# Altium schematic sheet Switcheo - on_off.SchDoc
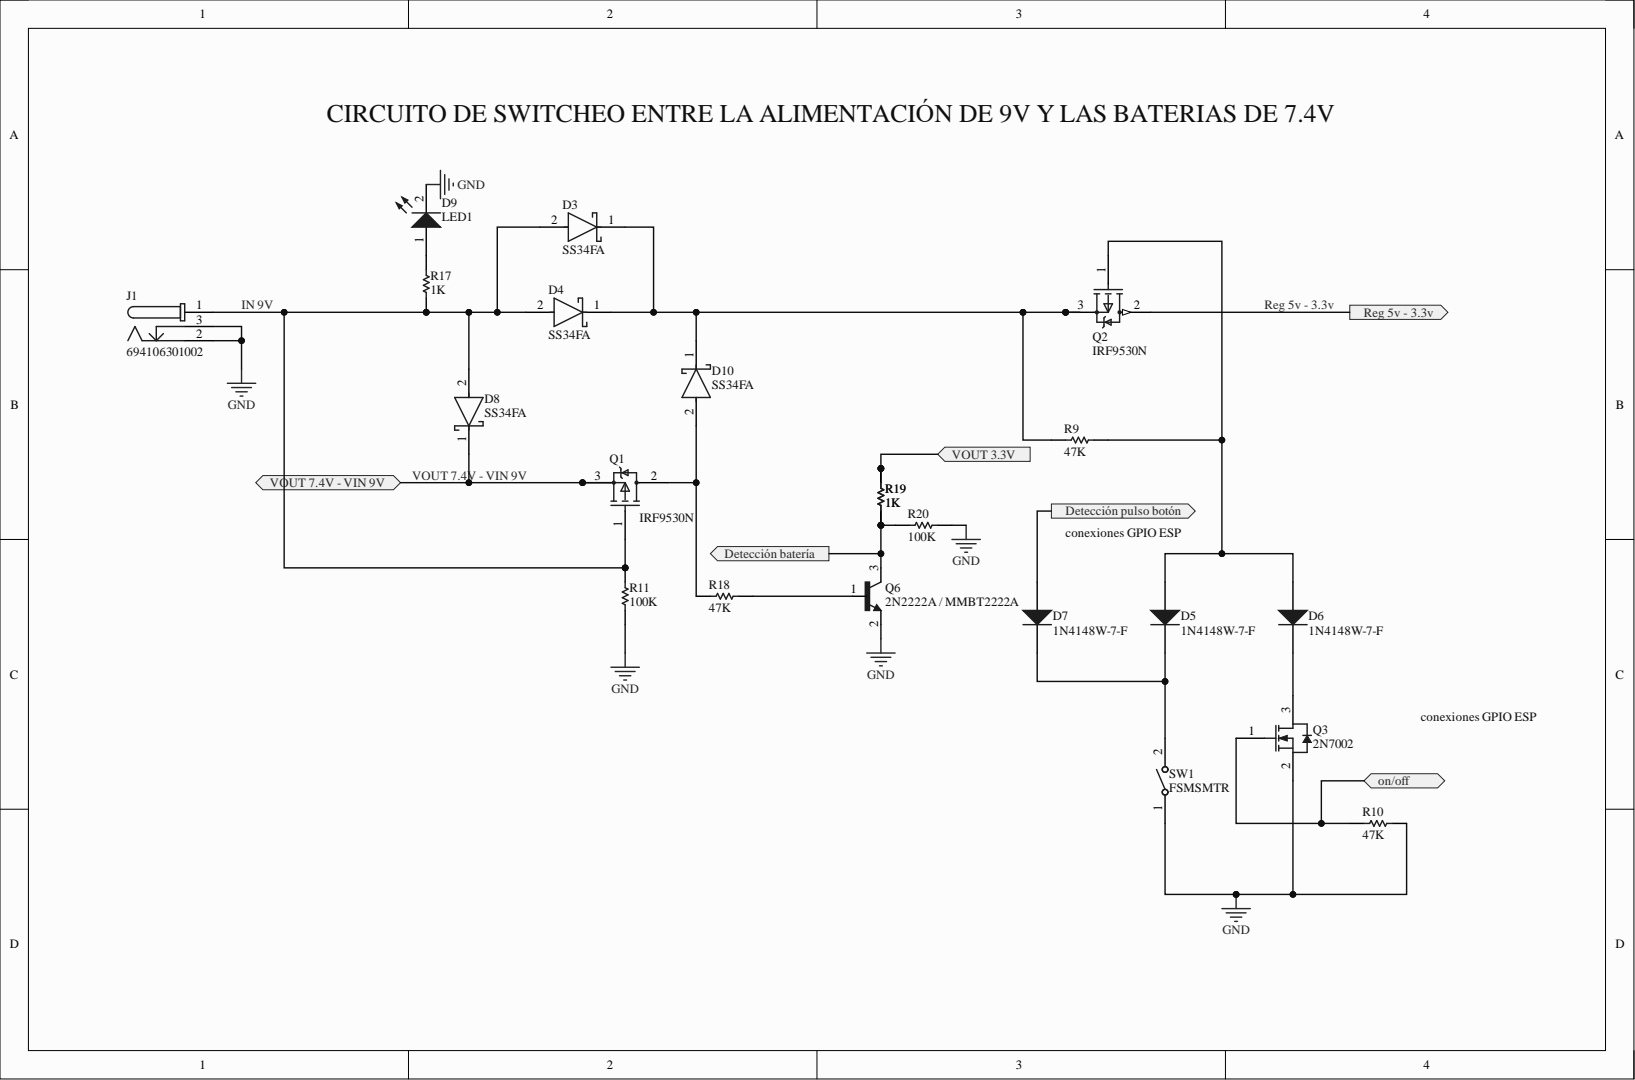
[diagram p0: Switcheo - on_off.SchDoc]

## Parts
D3  SS34FA  [Diodo_SS34]
D4  SS34FA  [Diodo_SS34]
D5  1N4148W-7-F  [1N4148]
D6  1N4148W-7-F  [1N4148]
D7  1N4148W-7-F  [1N4148]
D8  SS34FA  [Diodo_SS34]
D9  LED1  [Led0805 Verde]
D10  SS34FA  [Diodo_SS34]
J1  694106301002  [JACK DC]
Q1  IRF9530N  [IRF9530N THT]
Q2  IRF9530N  [IRF9530N THT]
Q3  2N7002  [2N7002]
Q6  2N2222A / MMBT2222A  [2N2222A / MMBT2222 SMD]
R9  value 47K  [RES 1206]  (hidden comment: 1%)
R10  value 47K  [RES 1206]  (hidden comment: 1%)
R11  1%  value 100K  [RES 1206]
R17  1%  value 1K  [RES 1206]
R18  value 47K  [RES 1206]  (hidden comment: 1%)
R19  1%  value 1K  [RES 1206]
R20  1%  value 100K  [RES 1206]
SW1  FSMSMTR  [switch]

## Ports
Detección batería, Detección pulso botón, on/off, Reg 5v - 3.3v, VOUT 3.3V, VOUT 7.4V - VIN 9V

## Nets
GND: D9.2 J1.2 J1.3 Q3.2 Q6.2 R10.2 R11.1 R20.2 SW1.1
Detección batería: Q6.3 R19.1 R20.1 ; port Detección batería
Detección pulso botón: D7.1 ; port Detección pulso botón
IN 9V: D3.2 D4.2 D8.2 J1.1 Q1.1 R11.2 R17.1
on/off: Q3.1 R10.1 ; port on/off
Reg 5v - 3.3v: Q2.2 ; port Reg 5v - 3.3v
VOUT 3.3V: R19.2 ; port VOUT 3.3V
VOUT 7.4V - VIN 9V: D8.1 Q1.3 ; port VOUT 7.4V - VIN 9V
NetD3_1: D3.1 D4.1 D10.1 Q2.3 R9.1
NetD5_1: D5.1 D6.1 Q2.1 R9.2
NetD5_2: D5.2 D7.2 SW1.2
NetD6_2: D6.2 Q3.3
NetD9_1: D9.1 R17.2
NetD10_2: D10.2 Q1.2 R18.1
NetQ6_1: Q6.1 R18.2

## Library data of the parts
D3 D4 D8 D10 = SS34FA: DIODE SCHOTTKY 40V 3A SOD123W; Component Kind: Standard; RoHS Compliant: Yes; Library Name: biblioteca esquematico sistema de drenaje.SchLib
D5-D7 = 1N4148: Fast Switching Diode, 100 V, 0.15 A, -65 to 150 degC, 2-Pin?SOD123, RoHS, Tape and Reel; Brand: DiodesIncorporated; Configuration: Single; If - Forward Current: 0.15A; Ir - Reverse Current: 1uA; Max Surge Current: 2A; Peak Reverse Voltage: 100V; Recovery Time: 4ns; Unit Weight: 0.000353oz; Vf - Forward Voltage: 1.25V; Component Kind: Standard; Datasheet URL: http://www.farnell.com/datasheets/1915529.pdf; Datasheet Version: Rev. 24-2, 10/2013; Factory Pack Quantity: 3000; Height: 1.25mm; Length: 2.85mm; Manufacturer: DiodesIncorporated; Manufacturer URL: http://www.diodes.com/; Maximum Operating Temperature: +150C; Minimum Operating Temperature: -65C; Mounting Style: SMD/SMT; Operating Temperature Range: -65Cto+150C; Package / Case: SOD-123; Package Reference: SOD123-2; Package URL: http://www.diodes.com/datasheets/ds18004.pdf; Packaging: Reel; Product: SwitchingDiodes; Product Category: Diodes-GeneralPurpose,Power,Switching; RoHS: True; Series: 1N4148; Type: SwitchingDiode; Width: 1.7mm; Library Name: biblioteca esquematico sistema de drenaje.SchLib
D9 = LED1: Typical RED GaAs LED; Component Kind: Standard; LatestRevisionDate: 08-Jul-2005; LatestRevisionNote: Mech Layer 1 removed.; PackageDescription: LED; 2 Leads; PackageReference: LED-1; Published: 8-Jun-2000; Simulation: LED1; Library Name: biblioteca esquematico sistema de drenaje.SchLib; Publisher: Altium Limited
J1 = JACK DC: Manufacturer: Wurth Electronics; NexarPartId: 52676100; Connector Type: Power; Current Rating: 5A; Gender: Male; Insulation Resistance: 100MΩ; Number of Contacts: 3; Operating Supply Voltage: 24V; Shielding: Unshielded; Voltage Rating (DC): 20V; Color: Black; Component Kind: Standard; Contact Gender: Female; Contact Material: Gold, Tin; Contact Plating: Tin; Flammability Rating: UL94 V-0; Height: 11mm; Housing Material: Polyamide; Manufacturer Part Number: 694106301002; Max Operating Temperature: 85°C; Min Operating Temperature: -40°C; Mount: Through Hole; Packaging: Bulk; REACH SVHC: Unknown; RoHS: Compliant; Termination: Through Hole; Voltage Rating: 24V; Library Name: biblioteca esquematico sistema de drenaje.SchLib
Q1 Q2 = IRF9530N: MOSFET P-CH 100V 14A D2PAK; Drain to Source Breakdown Voltage: -100V; Drain to Source Resistance: 200mR; Gate to Source Voltage (Vgs): 20V; Input Capacitance: 760pF; Max Power Dissipation: 3.8W; Power Dissipation: 79W; Rise Time: 58ns; Turn-Off Delay Time: 45ns; Turn-On Delay Time: 15ns; Case/Package: D2PAK; Component Kind: Standard; Contact Plating: Tin; Continuous Drain Current (ID): 14A; Drain to Source Voltage (Vdss): -100V; Halogen Free: Not Halogen Free; Height: 0.19inch; Lead Free: Contains Lead; Length: 0.42inch; Max Dual Supply Voltage: -100V; Max Operating Temperature: 175°C; Min Operating Temperature: -55°C; Mount: Surface Mount; Number of Pins: 3; Packaging: Tape and Reel; Rds On Max: 200mR; RoHS Compliant: Yes; Width: 0.38inch; Library Name: biblioteca esquematico sistema de drenaje.SchLib
Q3 = 2N7002: N-channel 60 V, 1.8 Ohm, 0.35 A STripFET(TM) II Power MOSFET, SOT23; Manufacturer: STMicroelectronics; Component Kind: Standard; ComponentLink1Description: Manufacturer URL; ComponentLink1URL: http://www.st.com/; ComponentLink2Description: Datasheet; ComponentLink2URL: http://www.st.com/st-web-ui/static/active/en/resource/technical/document/datasheet/CD00005134.pdf; DatasheetVersion: Rev. 9, 11/2008; Mounting Technology: Surface Mount; PackageDescription: 3-Pin SOT23, 3.016 x 2.8 mm Body, 0.95 mm Pitch; PackageReference: SOT-23; PartNumber: 2N7002; Library Name: biblioteca esquematico sistema de drenaje.SchLib
Q6 = 2N2222A / MMBT2222A: BJT, SOT-23, 40V, 600mA, NPN, 0.25W, 150°C; MF: Diotec Semiconductor; Check_prices: https://www.snapeda.com/parts/MMBT2222A/Diotec/view-part/?ref=eda; Component Kind: Standard; MP: MMBT2222A; Package: SOT-23 Diotec; SnapEDA_Link: https://www.snapeda.com/parts/MMBT2222A/Diotec/view-part/?ref=snap; Library Name: biblioteca esquematico sistema de drenaje.SchLib
R9-R11 R17-R20 = Resistor: Component Kind: Standard; Description: Resistor; LatestRevisionDate: 17-Jul-2002; LatestRevisionNote: Re-released for DXP Platform.; PackageDescription: Axial Device, Thru-Hole; 2 Leads; 0.3 in Pin Spacing; PackageReference: AXIAL-0.3; Published: 8-Jun-2000; Library Name: biblioteca esquematico sistema de drenaje.SchLib; Publisher: Altium Limited
SW1 = switch: FSMSM Push Button Switch, 50 mA, -35 to 85 degC, 2-Pin SMD, RoHS, Tape and Reel; Manufacturer: TE Connectivity; Actuator Length: 1.07mm; Actuator Material: Polyamide; Case\/Package: SMD/SMT; Contact Current Rating: 50mA; Contact Resistance: 100mOhm; Electrical Life: 20000Cycles; Illumination Type: Illuminated; Max Voltage Rating (DC): 12V; Operating Force: 180gf; Switch Travel: 0.25mm; Throw Configuration: SPST; Voltage Rating (DC): 24V; Component Kind: Standard; Contact Material: Brass; Contact Plating: Silver; Current Rating: 50mA; Datasheet URL: http://www.te.com/commerce/DocumentDelivery/DDEController?Action=showdoc&DocId=Customer+Drawing%7F1437566-3%7FC2%7Fpdf%7FEnglish%7FENG_CD_1437566-3_C2_1437566_3_1_c.dwg.pdf%7F1437566-4; Datasheet Version: Rev. C2, 08/2014; ELV: Compliant; Lead Free: LeadFree; Manufacturer URL: http://www.te.com/; Max Operating Temperature: 85degC; Mechanical Life: 20000Cycles; Min Operating Temperature: -35degC; Mount: SurfaceMount; Mounting Technology: SurfaceMount; Orientation: Straight; Package Description: 2-Pin Surface Mount Device, Body 6 x 3.5 mm, Pitch 9.19 mm; Package Quantity: 1800; Package Reference: FSMSMTR; Packaging: TapeandReel; REACH SVHC: Unknown; RoHSCompliant: true; Termination: GullWing; Library Name: biblioteca esquematico sistema de drenaje.SchLib
